# Altium schematic sheet Connectors.SchDoc
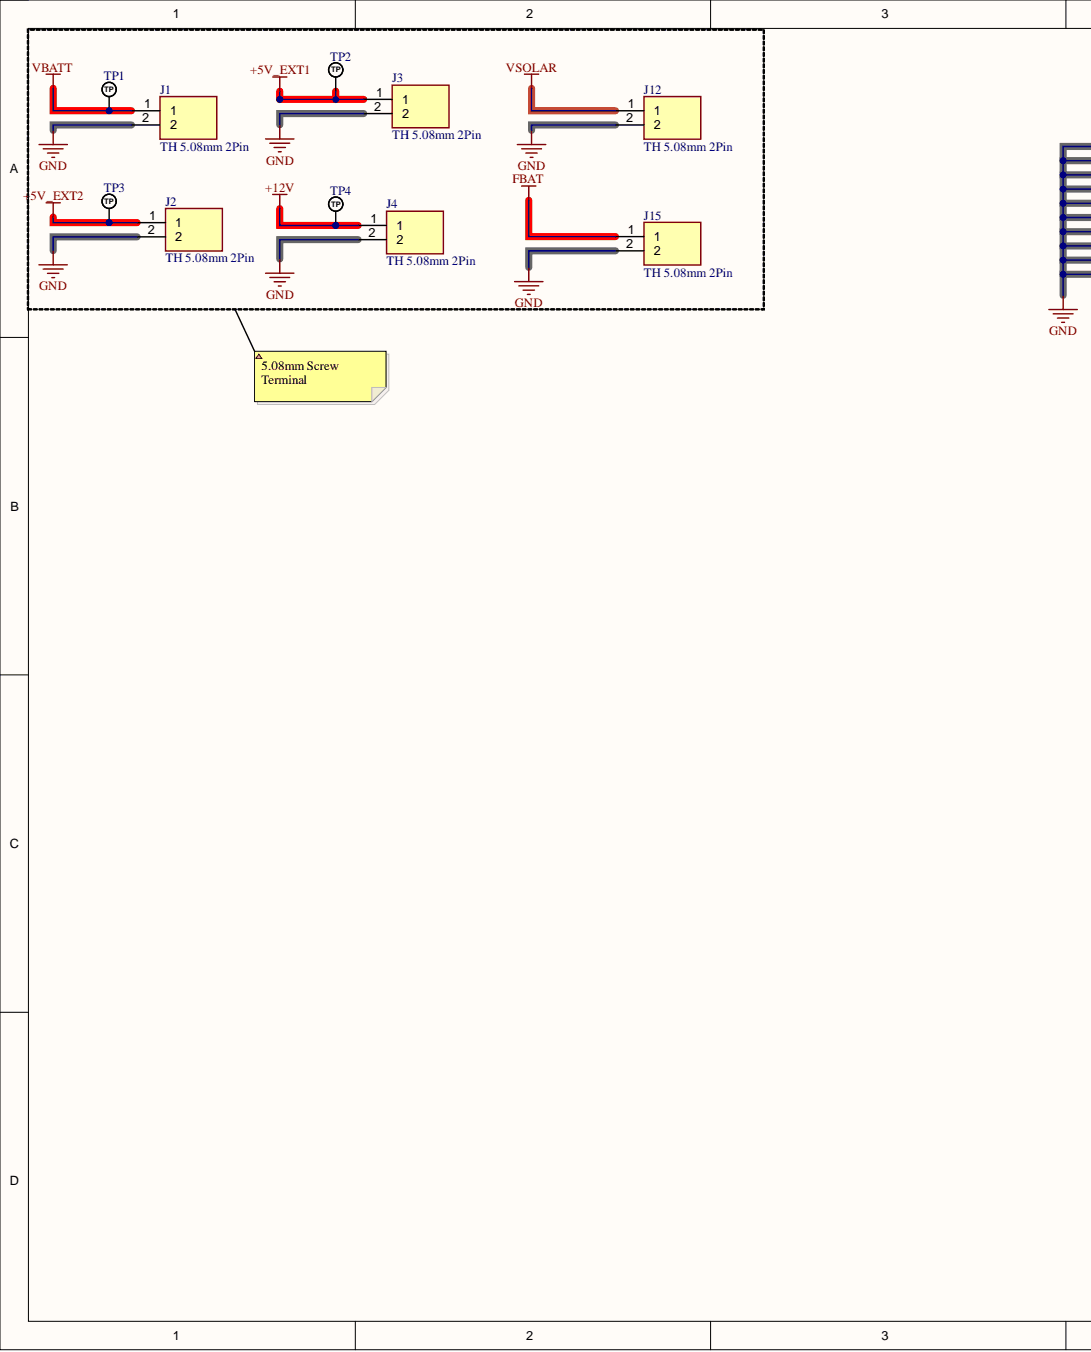
[diagram p0: Connectors.SchDoc, left]
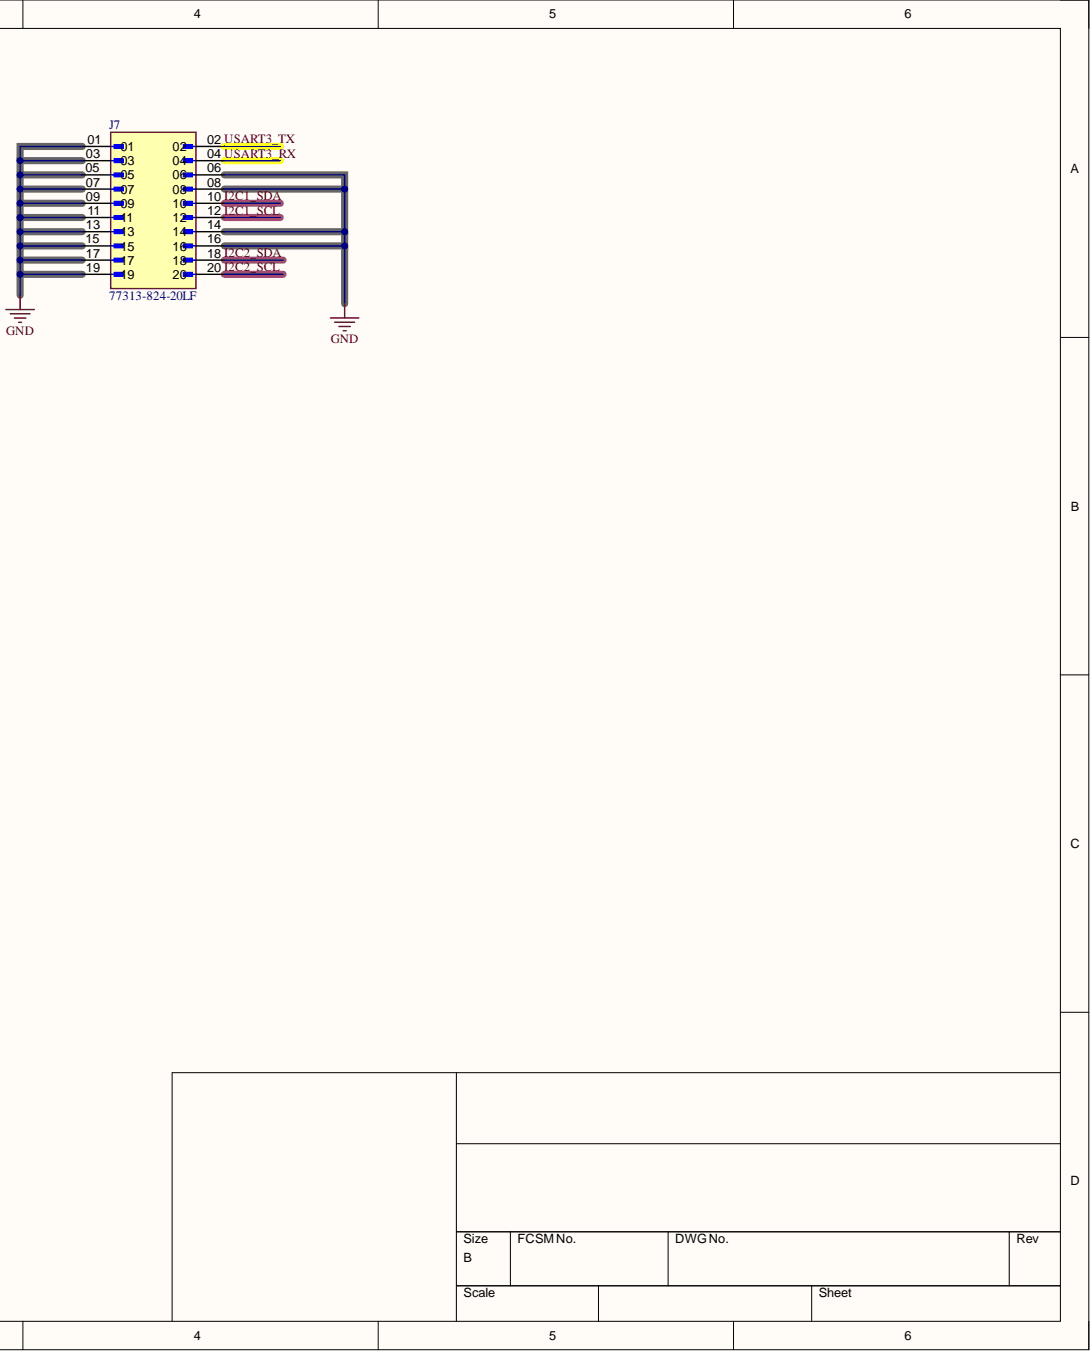
[diagram p1: Connectors.SchDoc, right]

## Parts
J1  TH 5.08mm 2Pin  [MC000048]
J2  TH 5.08mm 2Pin  [MC000048]
J3  TH 5.08mm 2Pin  [MC000048]
J4  TH 5.08mm 2Pin  [MC000048]
J7  77313-824-20LF  [AMPHENOL_77313-824-20LF]
J12  TH 5.08mm 2Pin  [MC000048]
J15  TH 5.08mm 2Pin  [MC000048]
TP1  TP_NOBOM  [PAD_RND_1MM]  (value not drawn)  (no BOM)
TP2  TP_NOBOM  [PAD_RND_1MM]  (value not drawn)  (no BOM)
TP3  TP_NOBOM  [PAD_RND_1MM]  (value not drawn)  (no BOM)
TP4  TP_NOBOM  [PAD_RND_1MM]  (value not drawn)  (no BOM)

## Nets
+5V_EXT1: J3.1 TP2.1
+5V_EXT2: J2.1 TP3.1
+12V: J4.1 TP4.1
FBAT: J15.1
GND: J1.2 J2.2 J3.2 J4.2 J7.01 J7.03 J7.05 J7.06 J7.07 J7.08 J7.09 J7.11 J7.13 J7.14 J7.15 J7.16 J7.17 J7.19 J12.2 J15.2
VBATT: J1.1 TP1.1
VSOLAR: J12.1
I2C1_SCL: J7.12
I2C1_SDA: J7.10
I2C2_SCL: J7.20
I2C2_SDA: J7.18
USART3_RX: J7.04
USART3_TX: J7.02

## Library data of the parts
J1-J4 J12 J15 = TH 5.08mm 2Pin: Connector; Manufacturer_Name: Multicomp Pro; Component Kind: Standard; Datasheet Link: http://www.farnell.com/datasheets/3186341.pdf; Height: 14.3mm; Manufacturer_Part_Number: MC000048; Library Name: STeRG-Passives-Standard.SchLib
J7 = 77313-824-20LF: BergStikÂ®, Board to Board connector, Unshrouded Header, 2.54 mm Pitch, Vertical, Double Row,Through Hole, 20 Positions; MF: Amphenol ICC (FCI); Availability: In Stock; Check_prices: https://www.snapeda.com/parts/77313-824-20LF/Amphenol+FCI/view-part/?ref=eda; Component Kind: Standard; Library Name: 77313-824-20LF.SchLib; MP: 77313-824-20LF; Package: None; Price: None; Purchase-URL: https://pricing.snapeda.com/search/part/77313-824-20LF/?ref=eda; SnapEDA_Link: https://www.snapeda.com/parts/77313-824-20LF/Amphenol+FCI/view-part/?ref=snap
TP1-TP4 = TEST_POINT_RND_1MM: Test Point Round 1mm (NO BOM); Library Name: STeRG-Passives-Standard.SchLib
